# Altium schematic sheet [07] - RS485 INTERFACE.SchDoc("[07] - RS485 INTERFACE")
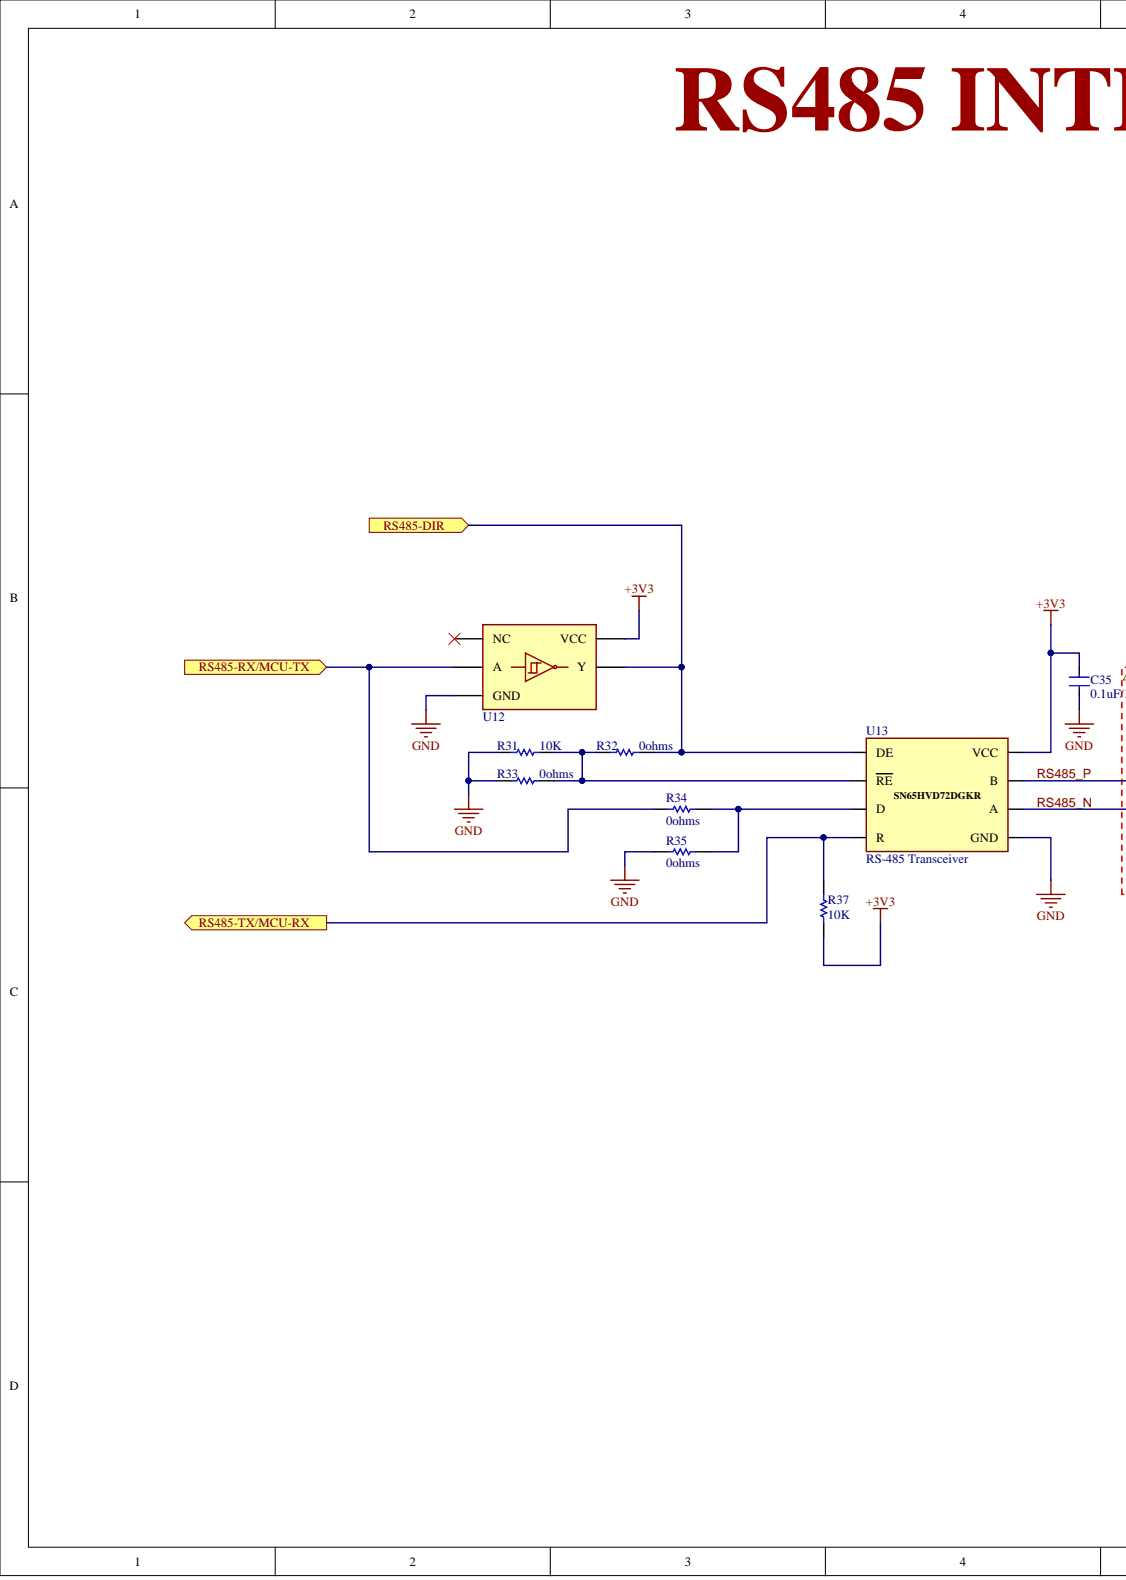
[diagram p0: [07] - RS485 INTERFACE.SchDoc, left]
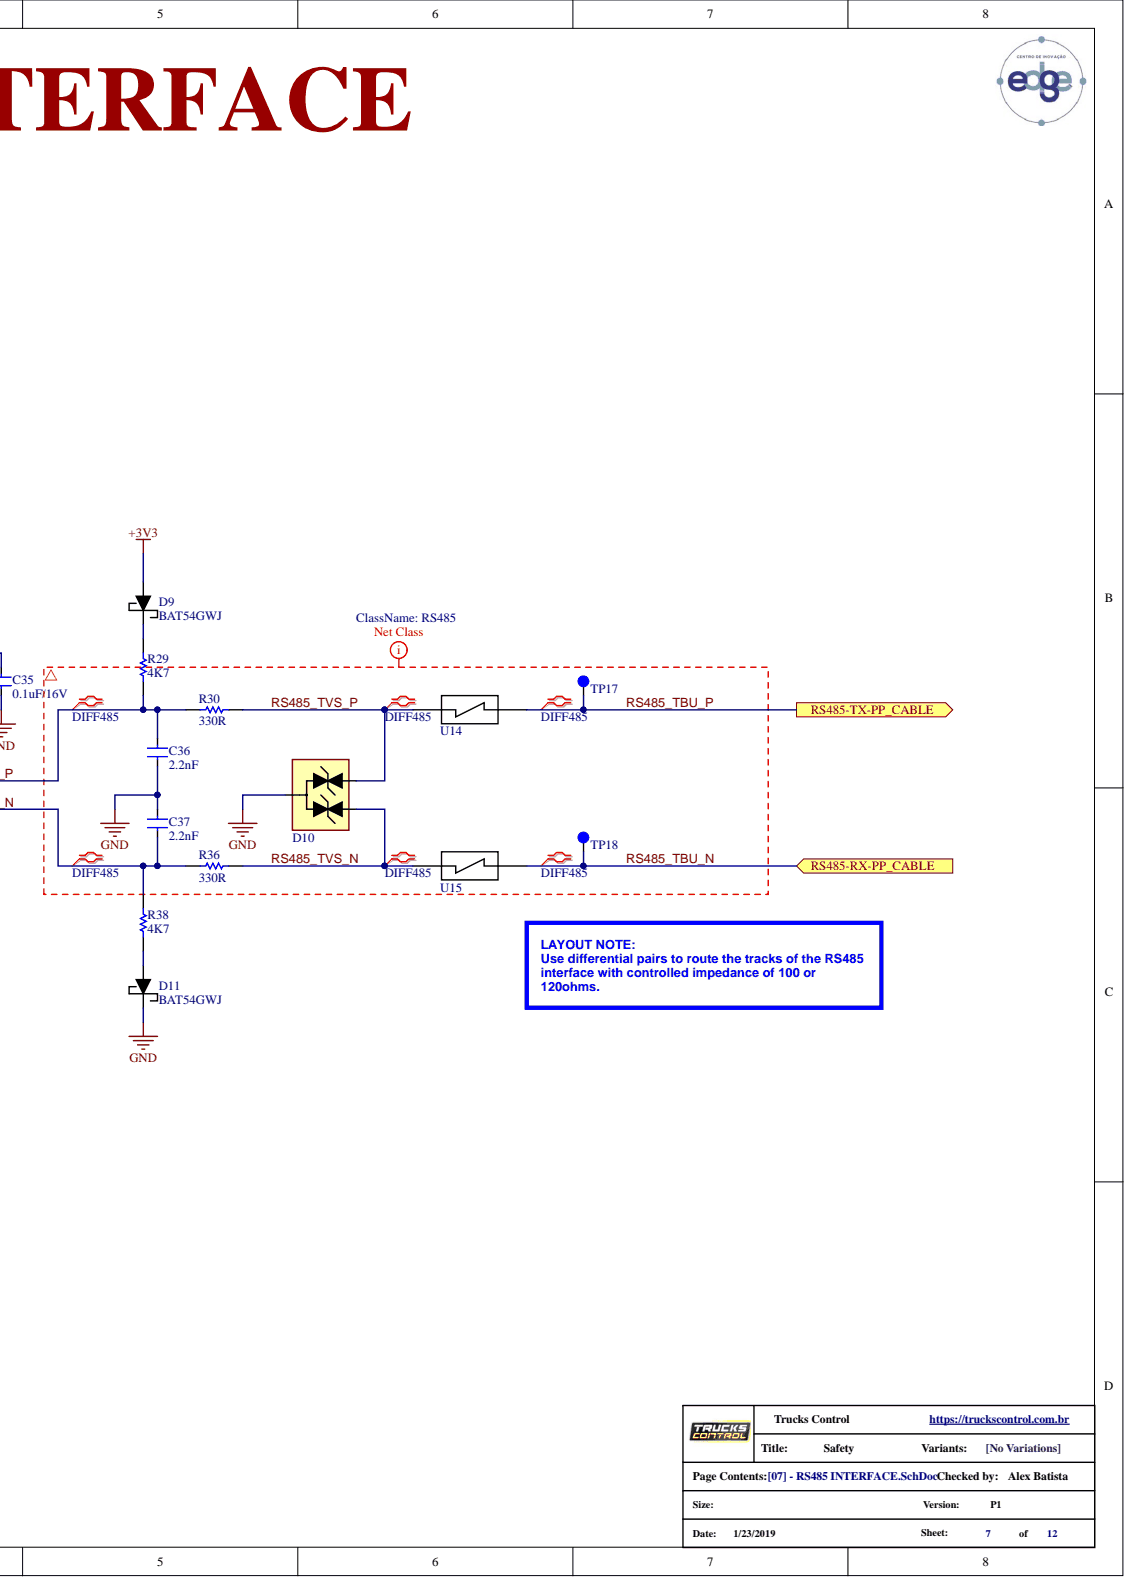
[diagram p1: [07] - RS485 INTERFACE.SchDoc, right]

## Parts
C35  0.1uF/16V  [C0402]
C36  2.2nF  [C0402]
C37  2.2nF  [C0402]
D9  BAT54GWJ  [SOD123]
D10  TVS Diode  [SOT-23]  (value not drawn)
D11  BAT54GWJ  [SOD123]
R29  4K7  [R0402]
R30  330R  [R0402]
R31  10K  [R0402]
R32  0ohms  [R0402]
R33  0ohms  [R0402]
R34  0ohms  [R0402]
R35  0ohms  [R0402]
R36  330R  [R0402]
R37  10K  [R0402]
R38  4K7  [R0402]
TP17  TestPoint  [Test Point]  (value not drawn)  (no BOM)
TP18  TestPoint  [Test Point]  (value not drawn)  (no BOM)
U12  74LVC1G14GW,125  [SOT353-1-74LVC1G14]  (value not drawn)
U13  RS-485 Transceiver  Logic Function: Transceiver  Interface: RS-485  [VSSOP-8]
U14  TBU  [DFN-3]  (value not drawn)
U15  TBU  [DFN-3]  (value not drawn)

## Ports
RS485-DIR, RS485-RX-PP_CABLE, RS485-RX/MCU-TX, RS485-TX-PP_CABLE, RS485-TX/MCU-RX

## Nets
+3V3: C35.2 D9.2 R37.1 U12.5(VCC) U13.8(VCC)
GND: C35.1 C36.1 C37.2 D10.3 D11.1 R31.1 R33.1 R35.1 U12.3(GND) U13.5(GND)
RS485-DIR: R32.2 U12.4(Y) U13.3(DE) ; port RS485-DIR
RS485-RX/MCU-TX: R34.1 U12.2(A) ; port RS485-RX/MCU-TX
RS485-TX/MCU-RX: R37.2 U13.1(R) ; port RS485-TX/MCU-RX
RS485_N: C37.1 R36.1 R38.2 U13.6(A)
RS485_P: C36.2 R29.1 R30.1 U13.7(B)
RS485_TBU_N: TP18.1 U15.3 ; port RS485-RX-PP_CABLE
RS485_TBU_P: TP17.1 U14.3 ; port RS485-TX-PP_CABLE
RS485_TVS_N: D10.2 R36.2 U15.1
RS485_TVS_P: D10.1 R30.2 U14.1
NetD9_1: D9.1 R29.2
NetD11_2: D11.2 R38.1
NetR31_2: R31.2 R32.1 R33.2 U13.2(RE)
NetR34_2: R34.2 R35.2 U13.4(D)
N00139:

Not connected: U12 1(NC)

## Library data of the parts
C35 = GCM155R71C104KA55D: 0.1UF 16V X7R 0402 CAP CER; Manufacturer: Murata; Tolerance: 10%; Capacitance: 100nF; Width: 0.02inch; Voltage Rating (DC): 16V; Voltage Rating: 16V; Voltage: 16V; Thickness: 0.022inch; Termination: SMD/SMT; Supplier Part Number 1: 81-GCM155R71C104KA5D; Supplier 1: Mouser; Stock 1: 3750168; REACH SVHC: No SVHC; Ratings: AEC-Q200; Radiation Hardening: No; Pricing 1: 1=0.1, 10=0.061, 50=0.061, 100=0.026, 1000=0.015, 10000=0.01 (USD); Packaging: Tape and Reel; Package Quantity: 1; Mount: Surface Mount; Min Operating Temperature: -55°C; Max Operating Temperature: 125°C; Manufacturer Part Number: GCM155R71C104KA55D; Length: 1mm; Lead Free: Lead Free; Height: 0.5mm; Dielectric: X7R; Depth: 0.5mm; Component Kind: Standard; Case Code (Metric): 1005; Case Code (Imperial): 0402; Case/Package: 0402; Library Name: tc-pcb-safety_V1I1.SchLib
C36 C37 = CC0402JRX7R9BB222: 2.2nF 50V X7R 5% 0402 Multilayer Ceramic Capacitors MLCC - SMD/SMT; Manufacturer: Yageo; Width: 0.02inch; Voltage Rating (DC): 50V; Voltage Rating: 50V; Tolerance: 5%; Thickness: 0.022inch; Termination: SMD/SMT; Supplier Part Number 1: 603-CC402JRX7R9BB222; Supplier 1: Mouser; Stock 1: 50000; Radiation Hardening: No; Pricing 1: 1=0.1, 10=0.024, 50=0.024, 100=0.011, 1000=0.009, 10000=0.006 (USD); Packaging: Tape and Reel; Package Quantity: 10000; Mount: Surface Mount; Min Operating Temperature: -55°C; Max Operating Temperature: 125°C; Manufacturer Part Number: CC0402JRX7R9BB222; Length: 1mm; Lead Free: Lead Free; Height: 0.5mm; Halogen Free: Halogen Free; Dielectric: X7R; Depth: 0.5mm; ComponentLink3URL: http://www.mouser.com/ds/2/447/Yageo_UPY-GP_NP0_16V-to-50V_9-358835.pdf; ComponentLink3Description: http://www.mouser.com/ds/2/447/Yageo_UPY-GP_NP0_16V-to-50V_9-358835.pdf; ComponentLink2URL: https://www.verical.com/server-webapp/html/getDatasheet?partID=662944; ComponentLink2Description: https://www.verical.com/server-webapp/html/getDatasheet?partID=662944; ComponentLink1URL: http://www.yageo.com/documents/recent/UPY-GPHC_X7R_6.3V-to-50V_8.pdf; ComponentLink1Description: http://www.yageo.com/documents/recent/UPY-GPHC_X7R_6.3V-to-50V_8.pdf; Component Kind: Standard; Case Code (Metric): 1005; Case Code (Imperial): 0402; Case/Package: 0402; Capacitance: 2.2nF; Library Name: tc-pcb-safety_V1I1.SchLib
D9 D11 = BAT54GWJ: Diode Schottky 0.2A Automotive 2-Pin SOD-123 T/R; Manufacturer: NXP Semiconductors; Supplier Part Number 1: 841-BAT54GWJ; Supplier 1: Mouser; Stock 1: 3376; Pricing 1: 1 = 0.14000, 10 = 0.12500, 50 = 0.12500, 100 = 0.04400, 1000 = 0.03000, 10000 = 0.02000 (USD); Manufacturer Part Number: BAT54GWJ; ComponentLink2URL: http://datasheet.octopart.com/BAT54GWJ-Nexperia-datasheet-82522515.pdf; ComponentLink2Description: http://datasheet.octopart.com/BAT54GWJ-Nexperia-datasheet-82522515.pdf; ComponentLink1URL: http://datasheet.octopart.com/BAT54GWJ-NXP-Semiconductors-datasheet-68295833.pdf; ComponentLink1Description: http://datasheet.octopart.com/BAT54GWJ-NXP-Semiconductors-datasheet-68295833.pdf; Component Kind: Standard; Library Name: tc-pcb-safety_V1I1.SchLib
D10 = CDSOT23-SM712: TVS DIODE 7VWM/12VWM SOT23; Manufacturer: Bourns; Working Voltage: 12V; Test Current: 1mA; Reverse Breakdown Voltage: 13.3V; Power Line Protection: No; Power Dissipation: 400W; Polarity: Bidirectional,Unidirectional; Peak Pulse Current: 17A; Number of Elements: 2; Max Surge Current: 30A; Max Reverse Leakage Current: 20uA; Max Power Dissipation: 600W; ESD Protection: Yes; Element Configuration: Dual; Direction: Bidirectional; Clamping Voltage: 26V; Capacitance: 75pF; Width: 0.055inch; Termination: SMD/SMT; Supplier Part Number 1: 652-CDSOT23-SM712; Supplier 1: Mouser; Stock 1: 8506; Reverse Standoff Voltage: 12V; REACH SVHC: No SVHC; Radiation Hardening: No; Pricing 1: 1=1.7, 10=1.37, 50=1.37, 100=1.05, 1000=0.731, 10000=0.63 (USD); Peak Pulse Power: 400W; Packaging: Tape and Reel; Package Quantity: 1; Number of Pins: 3; Number of Channels: 2; Number of Bidirectional Channels: 2; Mount: Surface Mount; Min Operating Temperature: -55°C; Min Breakdown Voltage: 13.3V; Max Operating Temperature: 150°C; Max Breakdown Voltage: 13.3V; Manufacturer Part Number: CDSOT23-SM712; Length: 3.04mm; Leakage Current: 20uA; Height: 1.11mm; Depth: 1.4mm; Contact Plating: Tin; Composition: Zener; Component Kind: Standard; Case/Package: SOT-23; Breakdown Voltage: 13.3V; Library Name: tc-pcb-safety_V1I1.SchLib
R29 R38 = RC0402FR-074K7L: 4K7 ohm, 50 V,  1%,, 0402 [1005 Metric], 63 mW,  RC Series YAGEO (PHYCOMP)         RC0402FR-074K7L             SMD Chip Resistor, Thick Film; Voltage Rating (DC): 50V; Temperature Coefficient: 100ppm/°C; Resistance: 4.7kR; Power Rating: 62.5mW; Width: 0.5mm; Voltage Rating: 50V; Tolerance: 1%; Termination: SMD/SMT; Supplier Part Number 1: 603-RC0402FR-074K7L; Supplier 1: Mouser; Stock 1: 1734729; REACH SVHC: No SVHC; Pricing 1: 1=0.1, 10=0.012, 50=0.012, 100=0.004, 1000=0.003, 10000=0.003 (USD); Packaging: Tape and Reel; Number of Pins: 2; Min Operating Temperature: -55°C; Military Standard: Not; Max Operating Temperature: 155°C; Manufacturer Part Number: RC0402FR-074K7L; Manufacturer: Yageo; Length: 1mm; Lead Free: Lead Free; Height: 0.32mm; Depth: 0.5mm; Composition: Thick Film; ComponentLink4URL: http://www.farnell.com/datasheets/1686128.pdf; ComponentLink4Description: http://www.farnell.com/datasheets/1686128.pdf; ComponentLink3URL: https://www.verical.com/server-webapp/html/getDatasheet?partID=328119; ComponentLink3Description: https://www.verical.com/server-webapp/html/getDatasheet?partID=328119; ComponentLink2URL: http://www.farnell.com/datasheets/1018594.pdf; ComponentLink2Description: http://www.farnell.com/datasheets/1018594.pdf; ComponentLink1URL: http://documents.rs-components.com/techlib://itc_doclist_GB.htm?stockno=6184558; ComponentLink1Description: http://documents.rs-components.com/techlib://itc_doclist_GB.htm?stockno=6184558; Component Kind: Standard; Case Code (Metric): 1005; Case Code (Imperial): 0402; Case/Package: 0402; Library Name: tc-pcb-safety_V1I1.SchLib
R30 R36 = RC0402JR-7D330RL: Resistor 330R 50V 0402 5%; Manufacturer: Yageo; Supplier Part Number 1: 603-RC0402JR-7D330RL; Supplier 1: Mouser; Stock 1: 179208; Pricing 1: 1=0.1, 10=0.01, 50=0.01, 100=0.004, 1000=0.003, 10000=0.002 (USD); Manufacturer Part Number: RC0402JR-7D330RL; ComponentLink1URL: http://datasheet.octopart.com/RC0402JR-7D330RL-Yageo-datasheet-73766026.pdf; ComponentLink1Description: http://datasheet.octopart.com/RC0402JR-7D330RL-Yageo-datasheet-73766026.pdf; Component Kind: Standard; Library Name: tc-pcb-safety_V1I1.SchLib
R31 R37 = RC0402JR-7D10KL: 10K OHM 5% 1/16W 0402; Manufacturer: Yageo; Supplier Part Number 1: 603-RC0402JR-7D10KL; Supplier 1: Mouser; Stock 1: 178452; Pricing 1: 1=0.1, 10=0.01, 50=0.01, 100=0.004, 1000=0.003, 10000=0.002 (USD); Manufacturer Part Number: RC0402JR-7D10KL; Component Kind: Standard; Library Name: tc-pcb-safety_V1I1.SchLib
R32-R35 = CRG0402ZR: 0 ohm, 50 V, 0402 [1005 Metric], 63 mW, CRG Series; Manufacturer: TE Connectivity; Voltage Rating (DC): 50V; Tolerance: 0%; Temperature Coefficient: 200ppm/°C; Resistance: 0mR; Power Rating: 63mW; Number of Resistors: 1; Insulation Resistance: 1GR; Width: 0.5mm; Weight: 2.3E-05oz; Voltage Rating: 50V; Termination: Solder; Supplier Part Number 1: 279-CRG0402ZR; Supplier 1: Mouser; Stock 1: 540000; REACH SVHC: Unknown; Radiation Hardening: No; Pricing 1: 10000=0.002 (USD); Packaging: Tape and Reel; Package Quantity: 10000; Number of Terminations: 2; Number of Pins: 2; Mount: Surface Mount; Min Operating Temperature: -55°C; Max Operating Temperature: 125°C; Manufacturer Part Number: CRG0402ZR; Length: 1mm; Height: 0.35mm; ELV: Compliant; Depth: 0.5mm; Composition: Thick Film; Component Kind: Standard; Case Code (Metric): 1005; Case Code (Imperial): 0402; Case/Package: 0402; Library Name: tc-pcb-safety_V1I1.SchLib
TP17 TP18 = Test_Point: Library Name: tc-pcb-safety_V1I1.SchLib
U12 = 74LVC1G14GW,125: IC SCHMITT-TRIG INV 5TSSOP; Manufacturer: NXP Semiconductors; Voltage: 5V; Turn-On Delay Time: 5ns; Schmitt Trigger Input: Yes; Quiescent Current: 200uA; Propagation Delay: 2.2ns; Output Current: 50mA; Number of Inputs: 1; Min Supply Voltage (DC): 1.65V; Max Voltage Rating (DC): 5.5V; Low Level Output Current: 32mA; Logic Function: Buffer,Inverter,Schmitt Trigger; High Level Output Current: -32mA; Width: 1.35mm; Weight: 0.0001lb; Termination: SMD/SMT; Supplier Part Number 1: 771-74LVC1G14GW-G; Supplier 1: Mouser; Stock 1: 56001; REACH SVHC: No SVHC; Radiation Hardening: No; Pricing 1: 1=0.28, 10=0.19, 50=0.19, 100=0.08, 1000=0.054, 10000=0.036 (USD); Packaging: Tape and Reel; Package Quantity: 3000; Number of Pins: 5; Number of Elements: 1; Number of Circuits: 1; Mount: Surface Mount; Min Supply Voltage: 1.65V; Min Operating Temperature: -40°C; Max Supply Voltage (DC): 5.5V; Max Supply Voltage: 5.5V; Max Operating Temperature: 125°C; Manufacturer Part Number: 74LVC1G14GW,125; Length: 2.25mm; Lead Free: Lead Free; Height: 1mm; Contact Plating: Tin; ComponentLink5URL: http://www.nxp.com/documents/data_sheet/74LVC1G14.pdf; ComponentLink5Description: http://www.nxp.com/documents/data_sheet/74LVC1G14.pdf; ComponentLink4URL: http://www.farnell.com/datasheets/2051435.pdf; ComponentLink4Description: http://www.farnell.com/datasheets/2051435.pdf; ComponentLink3URL: http://www.farnell.com/datasheets/1645573.pdf; ComponentLink3Description: http://www.farnell.com/datasheets/1645573.pdf; ComponentLink2URL: http://ics.nxp.com/logic/to/documents/data_sheet/74LVC1G14.pdf; ComponentLink2Description: http://ics.nxp.com/logic/to/documents/data_sheet/74LVC1G14.pdf; ComponentLink1URL: http://uk.rs-online.com/webdocs/13ec/0900766b813ec87e.pdf; ComponentLink1Description: http://uk.rs-online.com/webdocs/13ec/0900766b813ec87e.pdf; Component Kind: Standard; Case/Package: TSSOP; Library Name: tc-pcb-safety_V1I1.SchLib
U13 = SN65HVD72DGKR: IC TXRX RS485 3.3V 8VSSOP; Manufacturer: Texas Instruments; Simplex/Duplex: Half Duplex; Propagation Delay: 0.7us; Output Voltage: 2V; Output Current: 8mA; Number of Transmitters: 1; Nominal Supply Current: 950uA; Min Supply Voltage: 3V; Max Supply Voltage: 3.6V; Data Rate: 0.25Mbps; Supplier Part Number 1: 595-SN65HVD72DGKR; Supplier 1: Mouser; Stock 1: 11786; REACH SVHC: No SVHC; Radiation Hardening: No; Pricing 1: 1=2.57, 10=2.18, 50=2.18, 100=1.75, 1000=1.27, 10000=1.14 (USD); Packaging: Tape and Reel; Package Quantity: 2500; Operating Supply Voltage: 3.3V; Number of Transceivers: 1; Number of Receivers: 1; Number of Pins: 8; Number of Drivers: 1; Min Operating Temperature: -40°C; Max Operating Temperature: 125°C; Manufacturer Part Number: SN65HVD72DGKR; Lead Free: Lead Free; Component Kind: Standard; Case/Package: VSSOP; Library Name: tc-pcb-safety_V1I1.SchLib
U14 U15 = TBU-CA025-050-WH: SURGE SUPP TBU 50MA 250VIMP SMD; Manufacturer: Bourns; Working Voltage: 100V; Voltage Rating (DC): 250V; Reverse Standoff Voltage: 20V; Response Time: 1us; Peak Pulse Current: 100mA; Number of Circuits: 2; ESD Protection: Yes; Element Configuration: Single; Direction: Bidirectional; Width: 0.157inch; Weight: 0.076oz; Voltage Rating: 250V; Termination: Solder; Supplier Part Number 1: 652-TBU-CA025-050-WH; Supplier 1: Mouser; Stock 1: 23479; REACH SVHC: No SVHC; Pricing 1: 1=1.84, 10=1.49, 50=1.49, 100=1.19, 1000=0.863, 10000=0.77 (USD); Packaging: Tape and Reel; Package Quantity: 3000; Number of Pins: 12; Mount: Surface Mount; Min Operating Temperature: -40°C; Max Operating Temperature: 125°C; Manufacturer Part Number: TBU-CA025-050-WH; Length: 0.255inch; Height: 0.033inch; Current Rating: 50mA; Component Kind: Standard; Clamping Voltage: 250V; Case/Package: DFN; Library Name: tc-pcb-safety_V1I1.SchLib
